# Altium schematic sheet Power.SchDoc
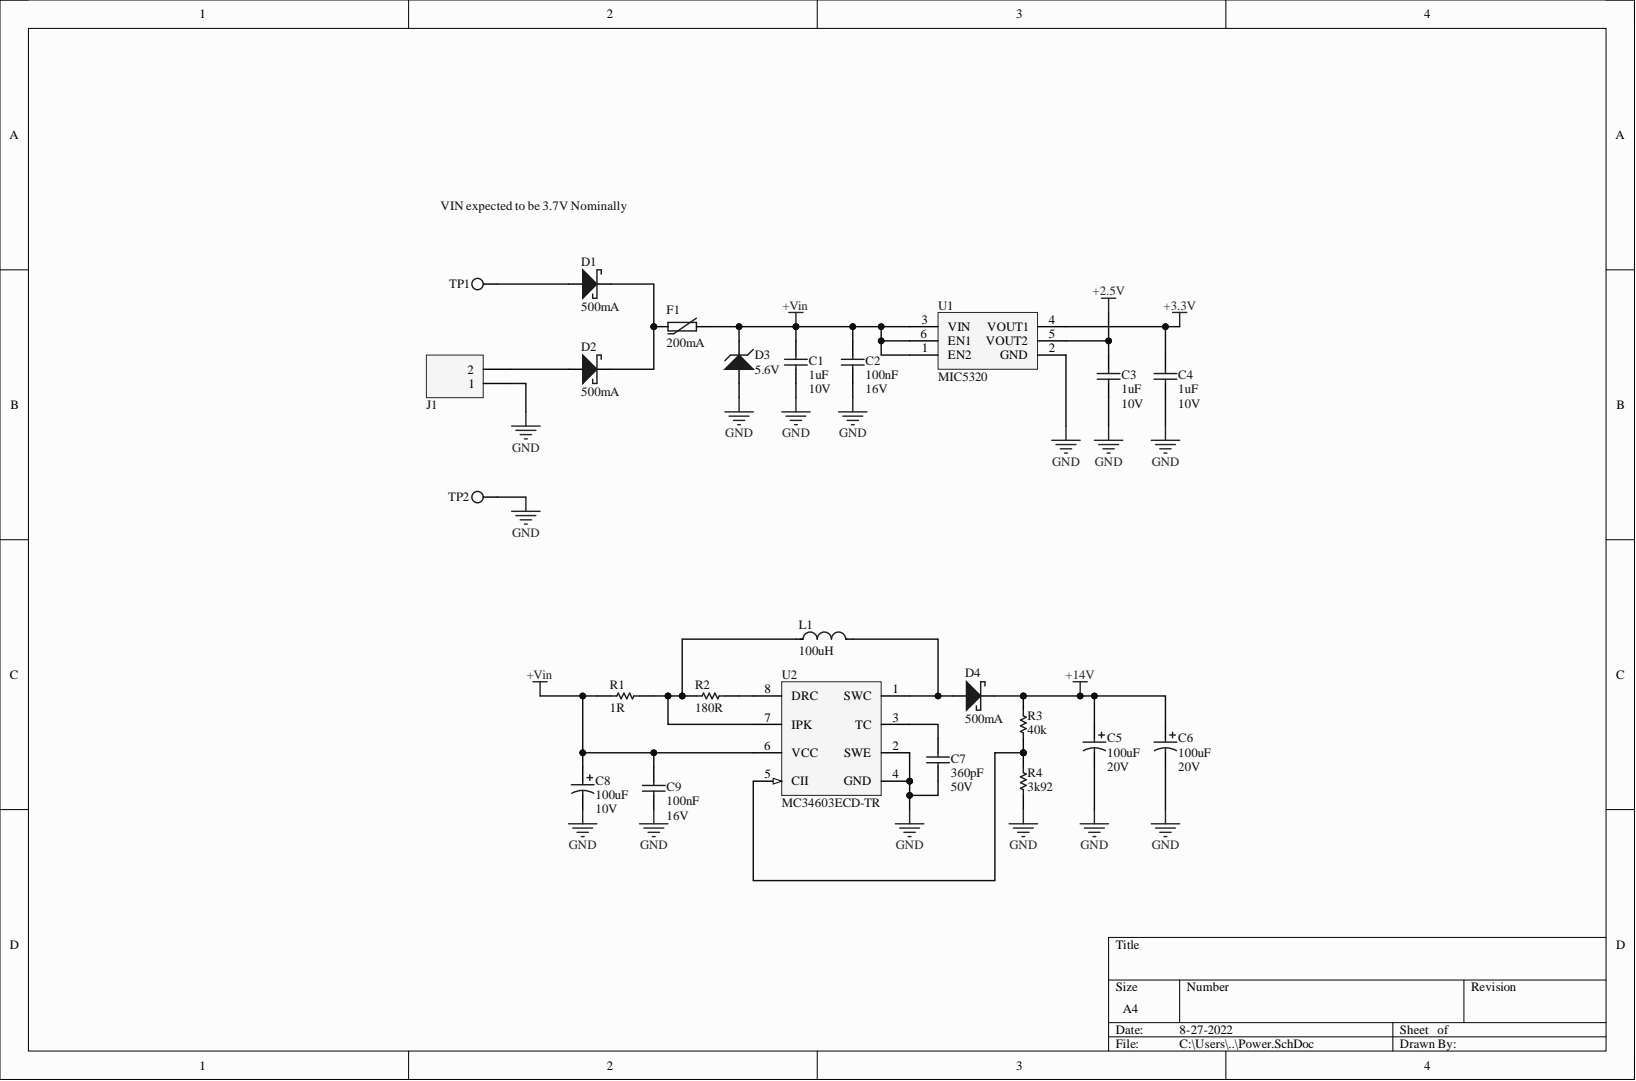
[diagram p0: Power.SchDoc]

## Parts
C1  value 1uF  Voltage: 10V  [0805_CAPACITOR]  (hidden comment: Capacitor)
C2  value 100nF  Voltage: 16V  [0805_CAPACITOR]  (hidden comment: Capacitor)
C3  value 1uF  Voltage: 10V  [0805_CAPACITOR]  (hidden comment: Capacitor)
C4  value 1uF  Voltage: 10V  [0805_CAPACITOR]  (hidden comment: Capacitor)
C5  value 100uF  Voltage: 20V  [2917_MOLDED_CAP]  (hidden comment: Cap_Pol)
C6  value 100uF  Voltage: 20V  [2917_MOLDED_CAP]  (hidden comment: Cap_Pol)
C7  value 360pF  Voltage: 50V  [0805_CAPACITOR]  (hidden comment: Capacitor)
C8  value 100uF  Voltage: 10V  [2312_MOLDED_CAP]  (hidden comment: Cap_Pol)
C9  value 100nF  Voltage: 16V  [0805_CAPACITOR]  (hidden comment: Capacitor)
D1  value 500mA  [DSS14U]  (hidden comment: Schottkey_Diode)
D2  value 500mA  [DSS14U]  (hidden comment: Schottkey_Diode)
D3  value 5.6V  [DDZ9690-7]  (hidden comment: Zener_Diode)
D4  value 500mA  [DSS14U]  (hidden comment: Schottkey_Diode)
F1  value 200mA  [1210_RESISTOR]  (hidden comment: Fuse)
J1  J000002  [Header_2]  (value not drawn)
L1  value 100uH  [SRN4018-101M]  (hidden comment: Inductor)
R1  value 1R  [0805_RESISTOR]  (hidden comment: Resistor)
R2  value 180R  [0805_RESISTOR]  (hidden comment: Resistor)
R3  value 40k  [0805_RESISTOR]  (hidden comment: Resistor)
R4  value 3k92  [0805_RESISTOR]  (hidden comment: Resistor)
TP1  =Value  [WIREPAD_SR_24AWG_SNGL]  (no BOM)
TP2  =Value  [WIREPAD_SR_24AWG_SNGL]  (no BOM)
U1  MIC5320  [MIC5320TSOT]
U2  MC34603ECD-TR  [SO8]

## Nets
+2.5V: C3.2 U1.5(VOUT2)
+3.3V: C4.2 U1.4(VOUT1)
+14V: C5.1 C6.1 D4.1 R3.2
+Vin: C1.2 C2.2 C8.1 C9.2 D3.1 F1.2 R1.2 U1.1(EN2) U1.3(VIN) U1.6(EN1) U2.6(VCC)
GND: C1.1 C2.1 C3.1 C4.1 C5.2 C6.2 C7.1 C8.2 C9.1 D3.2 J1.1 R4.1 TP2.1 U1.2(GND) U2.2(SWE) U2.4(GND)
NetC7_2: C7.2 U2.3(TC)
NetD1_1: D1.1 D2.1 F1.1
NetD1_2: D1.2 TP1.1
NetD2_2: D2.2 J1.2
NetD4_2: D4.2 L1.2 U2.1(SWC)
NetL1_1: L1.1 R1.1 R2.1 U2.7(IPK)
NetR2_2: R2.2 U2.8(DRC)
NetR3_1: R3.1 R4.2 U2.5(CII)

## Library data of the parts
C1 C3 C4 = C000003: Unpolarized Capacitor; Manufacterer Part Number: C0805C105M8RAC7800; Database Table Name: C - Capacitors$; Type: Ceramic X7R; Case: 0805; Component Kind: Standard; Datasheet: https://connect.kemet.com:7667/gateway/IntelliData-ComponentDocumentation/1.0/download/datasheet/C0805C105M8RACTU; Part Number: C000003; Library Name: Qureshi Database.DbLib
C2 C9 = C000004: Unpolarized Capacitor; Manufacterer Part Number: C0805C104K4RECAUTO; Database Table Name: C - Capacitors$; Type: Ceramic X7R; Case: 0805; Component Kind: Standard; Datasheet: https://connect.kemet.com:7667/gateway/IntelliData-ComponentDocumentation/1.0/download/datasheet/C0805C104K4RECAUTO; Part Number: C000004; Library Name: Qureshi Database.DbLib
C5 C6 = C000009: Polarized Capacitor; Manufacterer Part Number: 293D107X9020D2TE3; Database Table Name: C - Capacitors$; Tolerance: 0.1; Type: Tantalum; Case: 2917; Component Kind: Standard; Datasheet: https://www.vishay.com/docs/40002/293d.pdf; Part Number: C000009; Library Name: Qureshi Database.DbLib
C7 = C000002: Unpolarized Capacitor; Manufacterer Part Number: C0805C361J5GAC7800; Database Table Name: C - Capacitors$; Type: Ceramic C0G; Case: 0805; Component Kind: Standard; Datasheet: https://connect.kemet.com:7667/gateway/IntelliData-ComponentDocumentation/1.0/download/datasheet/C0805C361J5GACTU; Part Number: C000002; Library Name: Qureshi Database.DbLib
C8 = C000001: Polarized Capacitor; Manufacterer Part Number: 293D107X0010C2TE3; Database Table Name: C - Capacitors$; Type: Tantalum; Case: 2312; Component Kind: Standard; Datasheet: https://www.mouser.ca/datasheet/2/427/293d-1763499.pdf; Part Number: C000001; Library Name: Qureshi Database.DbLib
D1 D2 D4 = D000001: Schottkey Diode; Database Table Name: D - Diodes$; Component Kind: Standard; Datasheet: https://www.smc-diodes.com/propdf/DSS12U%20THRU%20DSS125U%20N1873%20REV.A.pdf; Manufacterer Part Number: DSS14U; Part Number: D000001; Library Name: Qureshi Database.DbLib
D3 = D000002: Zener Diode; Database Table Name: D - Diodes$; Component Kind: Standard; Datasheet: https://www.diodes.com/assets/Datasheets/ds30410.pdf; Manufacterer Part Number: DDZ9690-7; Part Number: D000002; Library Name: Qureshi Database.DbLib
F1 = R000008: Manufacterer Part Number: 0ZCH0020FF2E; Database Table Name: R - Resistors$; Inv Qty: 49; Case: 1210; Component Kind: Standard; Part Number: R000008; Library Name: Qureshi Database.DbLib
J1 = J000002: Header 2; Database Table Name: J - Jacks$; Component Kind: Standard; Part Number: J000002; Type: 2 POS Rect Header; Library Name: Qureshi Database.DbLib
L1 = L000001: Database Table Name: L - Inductors$; Maximum Current (Irms): 420mA; Resistance (ohms): 1.596; Component Kind: Standard; Datasheet: https://www.bourns.com/docs/Product-Datasheets/SRN4018.pdf; Description: Inductor; Manufacterer Part Number: SRN4018-101M; Part Number: L000001; Library Name: Qureshi Database.DbLib
R1 = R000001: Manufacterer Part Number: CRCW08051R00FKEAC; Database Table Name: R - Resistors$; Inv Qty: 0; Power Rating: 125mW; Tolerance: 0.01; Case: 0805; Component Kind: Standard; Datasheet: https://www.mouser.ca/datasheet/2/427/crcwce3-1762584.pdf; Part Number: R000001; Library Name: Qureshi Database.DbLib
R2 = R000002: Manufacterer Part Number: CRCW0805180RFKEAC; Database Table Name: R - Resistors$; Inv Qty: 0; Power Rating: 125mW; Tolerance: 0.01; Case: 0805; Component Kind: Standard; Datasheet: https://www.mouser.ca/datasheet/2/427/crcwce3-1762584.pdf; Part Number: R000002; Library Name: Qureshi Database.DbLib
R3 = R000003: Manufacterer Part Number: CRCW080540K0FKEA; Database Table Name: R - Resistors$; Inv Qty: 0; Power Rating: 125mW; Tolerance: 0.01; Case: 0805; Component Kind: Standard; Datasheet: https://www.mouser.ca/datasheet/2/427/crcwce3-1762584.pdf; Part Number: R000003; Library Name: Qureshi Database.DbLib
R4 = R000004: Manufacterer Part Number: CRCW08053K92FKEB; Database Table Name: R - Resistors$; Inv Qty: 0; Power Rating: 125mW; Tolerance: 0.01; Case: 0805; Component Kind: Standard; Datasheet: https://www.mouser.ca/datasheet/2/427/crcwce3-1762584.pdf; Part Number: R000004; Library Name: Qureshi Database.DbLib
TP1 TP2 = J000005: Database Table Name: J - Jacks$; Part Number: J000005; Library Name: Qureshi Database.DbLib
U1 = U000005: 3.3V 2CH Regulator; Manufacterer Part Number: MIC5320-SJYD6-TR; Database Table Name: U - ICs$; Inv Qty: 0; Component Kind: Standard; Datasheet Link: https://ww1.microchip.com/downloads/en/DeviceDoc/mic5320.pdf; Part Number: U000005; Library Name: Qureshi Database.DbLib
U2 = U000002: Manufacterer Part Number: MC34063ECD-TR; Database Table Name: U - ICs$; Inv Qty: 0; Component Kind: Standard; Datasheet Link: https://www.st.com/content/ccc/resource/technical/document/datasheet/03/f9/c4/3d/7f/eb/4c/5e/CD00001232.pdf/files/CD00001232.pdf/jcr:content/translations/en.CD00001232.pdf; Description: MC34603ECD-TR; Part Number: U000002; Library Name: Qureshi Database.DbLib
